# Altium schematic sheet Audio_DAC.SchDoc(Audio_DAC)
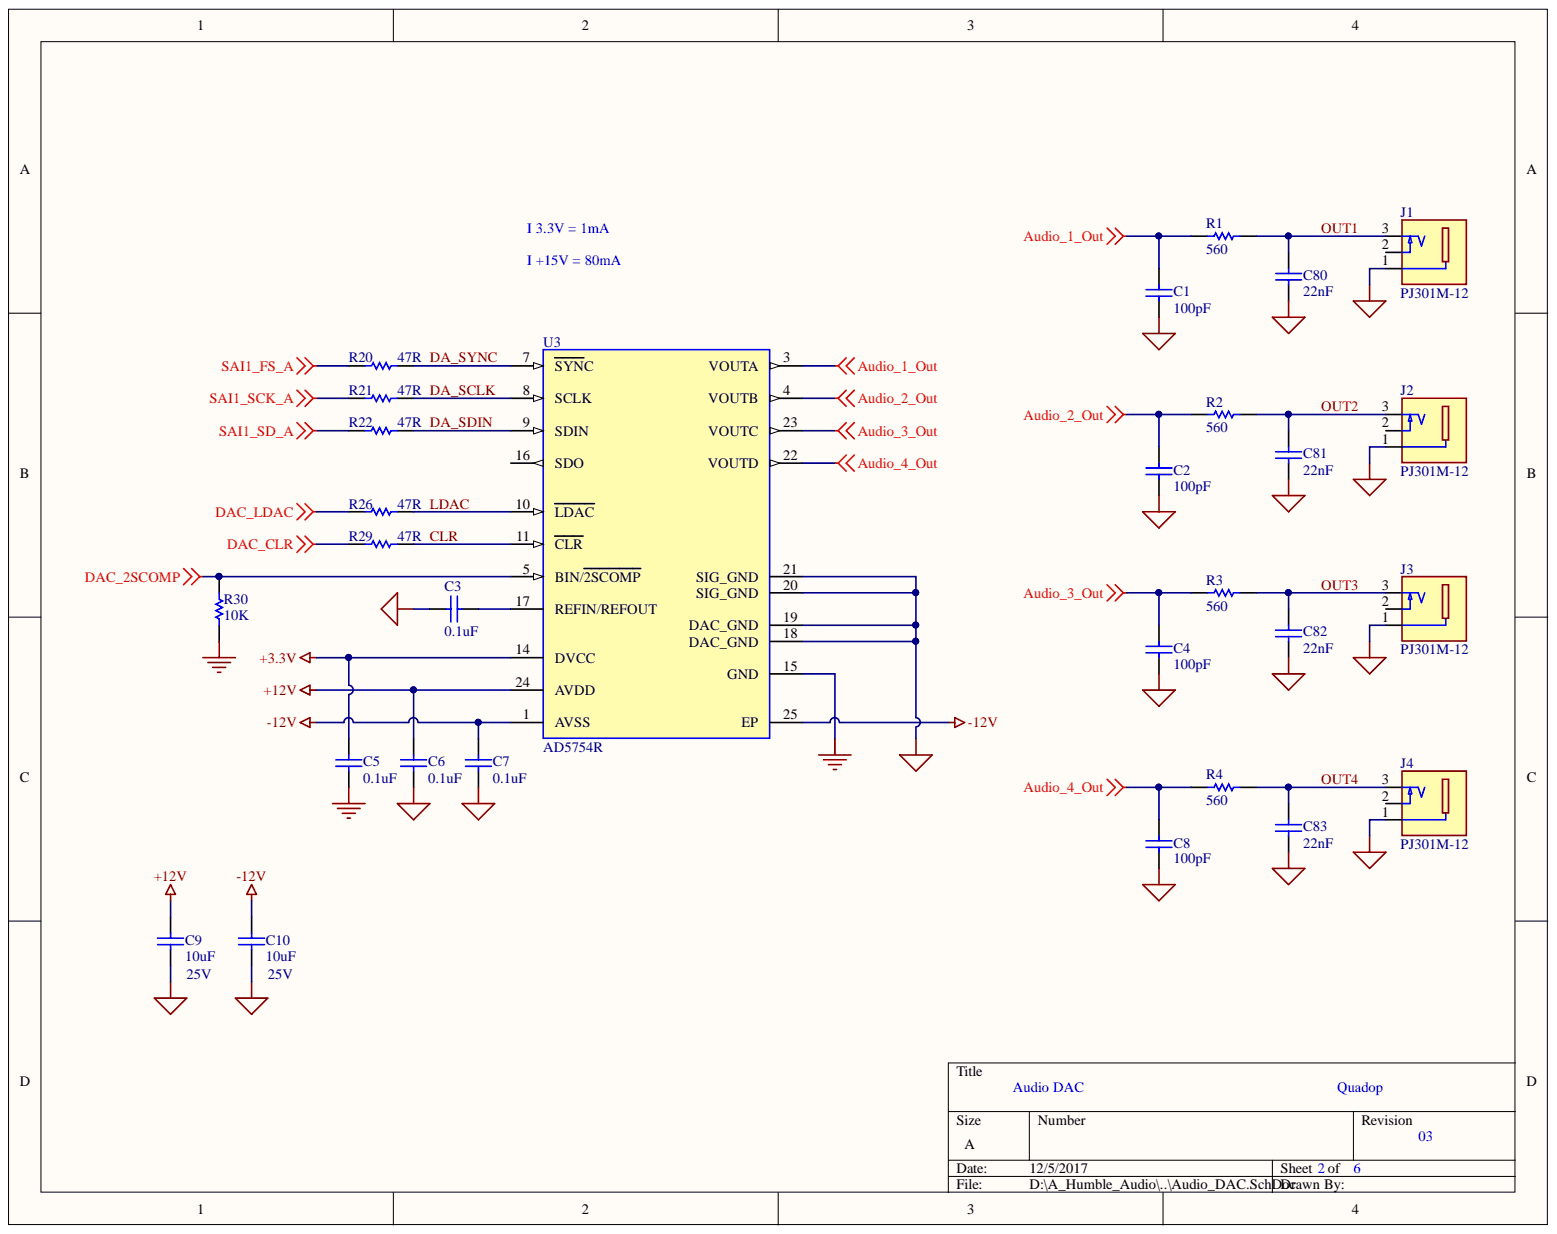
[diagram p0: Audio_DAC.SchDoc]

## Parts
C1  100pF  [C0603]
C2  100pF  [C0603]
C3  0.1uF  [C0603]
C4  100pF  [C0603]
C5  0.1uF  [C0603]
C6  0.1uF  [C0603]
C7  0.1uF  [C0603]
C8  100pF  [C0603]
C9  10uF  Voltage: 25V  [C0805]
C10  10uF  Voltage: 25V  [C0805]
C80  22nF  [C0603]
C81  22nF  [C0603]
C82  22nF  [C0603]
C83  22nF  [C0603]
J1  PJ301M-12  [EP_J_3.5_PJ301M-12]
J2  PJ301M-12  [EP_J_3.5_PJ301M-12]
J3  PJ301M-12  [EP_J_3.5_PJ301M-12]
J4  PJ301M-12  [EP_J_3.5_PJ301M-12]
R1  560  [R0603]
R2  560  [R0603]
R3  560  [R0603]
R4  560  [R0603]
R20  47R  [R0603]
R21  47R  [R0603]
R22  47R  [R0603]
R26  47R  [R0603]
R29  47R  [R0603]
R30  10K  [R0603]
U3  AD5754R  [TSSOP24_EP_0.65/4.5X7.9]

## Nets
+3.3V: C5.1 U3.14(DVCC)
+12V: C6.1 C9.1 U3.24(AVDD)
-12V: C7.1 C10.1 U3.1(AVSS) U3.25(EP)
AGND: C1.2 C2.2 C3.1 C4.2 C6.2 C7.2 C8.2 C9.2 C10.2 C80.2 C81.2 C82.2 C83.2 J1.1 J2.1 J3.1 J4.1 U3.18(DAC_GND) U3.19(DAC_GND) U3.20(SIG_GND) U3.21(SIG_GND)
Audio_1_Out: C1.1 R1.1 U3.3(VOUTA)
Audio_2_Out: C2.1 R2.1 U3.4(VOUTB)
Audio_3_Out: C4.1 R3.1 U3.23(VOUTC)
Audio_4_Out: C8.1 R4.1 U3.22(VOUTD)
DAC_2SCOMP: R30.2 U3.5(BIN/2SCOMP)
DAC_CLR: R29.1
DAC_LDAC: R26.1
GND: C5.2 R30.1 U3.15(GND)
SAI1_FS_A: R20.1
SAI1_SCK_A: R21.1
SAI1_SD_A: R22.1
CLR: R29.2 U3.11(CLR)
DA_SCLK: R21.2 U3.8(SCLK)
DA_SDIN: R22.2 U3.9(SDIN)
DA_SYNC: R20.2 U3.7(SYNC)
LDAC: R26.2 U3.10(LDAC)
OUT1: C80.1 J1.3 R1.2
OUT2: C81.1 J2.3 R2.2
OUT3: C82.1 J3.3 R3.2
OUT4: C83.1 J4.3 R4.2
NetC3_2: C3.2 U3.17(REFIN/REFOUT)

Not connected: J1 2; J2 2; J3 2; J4 2; U3 16(SDO)

## Library data of the parts
C1 C2 C4 C8 = 00000112: 100pF 50V 5% NP0 0603; PSpice: C; Lead time: Stock; Database Table Name: Capacitor; Voltage: 50V; Value: 100pF; Tolerance: 5%; Tape Width: 8mm; SMD: Yes; Price: 0.015; Part Type: Ceramic; Part Number: 00000112; MOQ: 4000; Main distributor: Rutronik; Component Kind: Standard; Availability: In Stock; Library Name: Gedo_DB.DbLib
C3 C5-C7 = 00000538: 100nF 50V +-10% X7R 0603 -55+125; Manufacturer Part Number: GRM188R71H104KA93J; Manufacturer: MURATA; PSpice: C; Lead time: Stock; Database Table Name: Capacitor; Voltage: 50V; Value: 0.1uF; Tolerance: +-10%; Tape Width: 8mm; SMD: Yes; Price: 0.0048; Part Type: Ceramic; Part Number: 00000538; Package: 10000T&R330mm; MOQ: 4000; Main distributor: Rutronik; Distributor: Rutronik; Component Kind: Standard; Availability: In Stock; ActivepartsID: http://search.murata.co.jp/Ceramy/GrsearchViewAction.do;jsessionid=C4D720578E4D2E51EC807AFF78C1B5C3; Library Name: Gedo_DB.DbLib
C9 C10 = 00106253: 10uF 25V 10% X5R 0805 -55+85; Manufacturer Part Number: TMK212BBJ106KG-T; Manufacturer: Taiyo Yuden; Tolerance: +-10%; PSpice: C; Database Table Name: Capacitor; Value: 10uF; Tape Width: 8mm; SMD: Yes; Price: 0.385; Part Type: Ceramic; Part Number: 00106253; Package: 3000T&R; Distributor Part Number: 587-2985-1-ND; Distributor: Digi-Key; Component Kind: Standard; Library Name: Gedo_DB.DbLib
C80-C83 = 00000135: 22nF 50V 10% X7R 0603; PSpice: C; Database Table Name: Capacitor; Voltage: 50V; Value: 22nF; Tolerance: 10%; Tape Width: 8mm; SMD: Yes; Part Type: Ceramic; Part Number: 00000135; Component Kind: Standard; Library Name: Gedo_DB.DbLib
J1-J4 = 72502011: 3pin EARPHONE JACK PANEL MOUNT VERTICAL F3.5; 0.5A 30V; Manufacturer: Thonkiconn; Database Table Name: Connectors; Value: PJ301M-12; Price: 0.48; Part Type: EarPhone jack F3.5; Part Number: 72502011; Manufacturer Part Number: PJ301M-12; Distributor: THONKICONN; Component Kind: Standard; Library Name: Gedo_DB.DbLib
R1-R4 = 11056002: 560R 1% 0603 1/10W 100ppm; Manufacturer Part Number: RC0603FR-07560RL; Manufacturer: YAGEO; PSpice: R; Database Table Name: Resistor; Value: 560; Tolerance: 1%; Tape Width: 8mm; SMD: Yes; Price: 0.013; Power: 1/10W; Part type: SMD 1%; Part Number: 11056002; Package: 5000T&R; Distributor Part Number: 311-560HRCT-ND; Distributor: Digi-Key; Component Kind: Standard; Availability: In Stock; Library Name: Gedo_DB.DbLib
R20-R22 R26 R29 = 11047092: 47R 1% 0603 1/10W 100ppm; Manufacturer Part Number: RC0603FR-0747RL; Manufacturer: YAGEO; PSpice: R; Database Table Name: Resistor; Value: 47R; Tolerance: 1%; Tape Width: 8mm; SMD: Yes; Price: 0.013; Power: 1/10W; Part type: SMD 1%; Part Number: 11047092; Package: 5000T&R; Distributor Part Number: 311-47.0HRTR-ND; Distributor: Digi-Key; Component Kind: Standard; Availability: In Stock; Library Name: Gedo_DB.DbLib
R30 = 11010022: 10K 1% 0603 1/10W 100ppm; Manufacturer Part Number: RC0603FR-0710KL; Manufacturer: YAGEO; PSpice: R; Database Table Name: Resistor; Value: 10K; Tolerance: 1%; Tape Width: 8mm; SMD: Yes; Price: 0.0057; Power: 1/10W; Part type: SMD 1%; Part Number: 11010022; Package: 5000T&R; Distributor Part Number: 311-10.0KHRCT-ND; Distributor: Digi-Key; Component Kind: Standard; Availability: In Stock; Library Name: Gedo_DB.DbLib
U3 = 50655754: 16-bit DAC, SPI/DSP, 4 channels, 4.5-16V, TSSOP-24, -40+85; Manufacturer Part Number: AD5754RBREZ; Manufacturer: Analog Devices Inc; Database Table Name: IC; Value: AD5754R; Tape Width: 16mm; SMD: Yes; Price: 24.5; Part Type: DAC; Part Number: 50655754; Package: 1000T&R; Distributor Part Number: AD5754RBREZ-ND; Distributor: Digi-Key; Component Kind: Standard; Library Name: Gedo_DB.DbLib
